# Altium schematic sheet FPGA_CONFIG.SchDoc(FPGA_CONFIG)
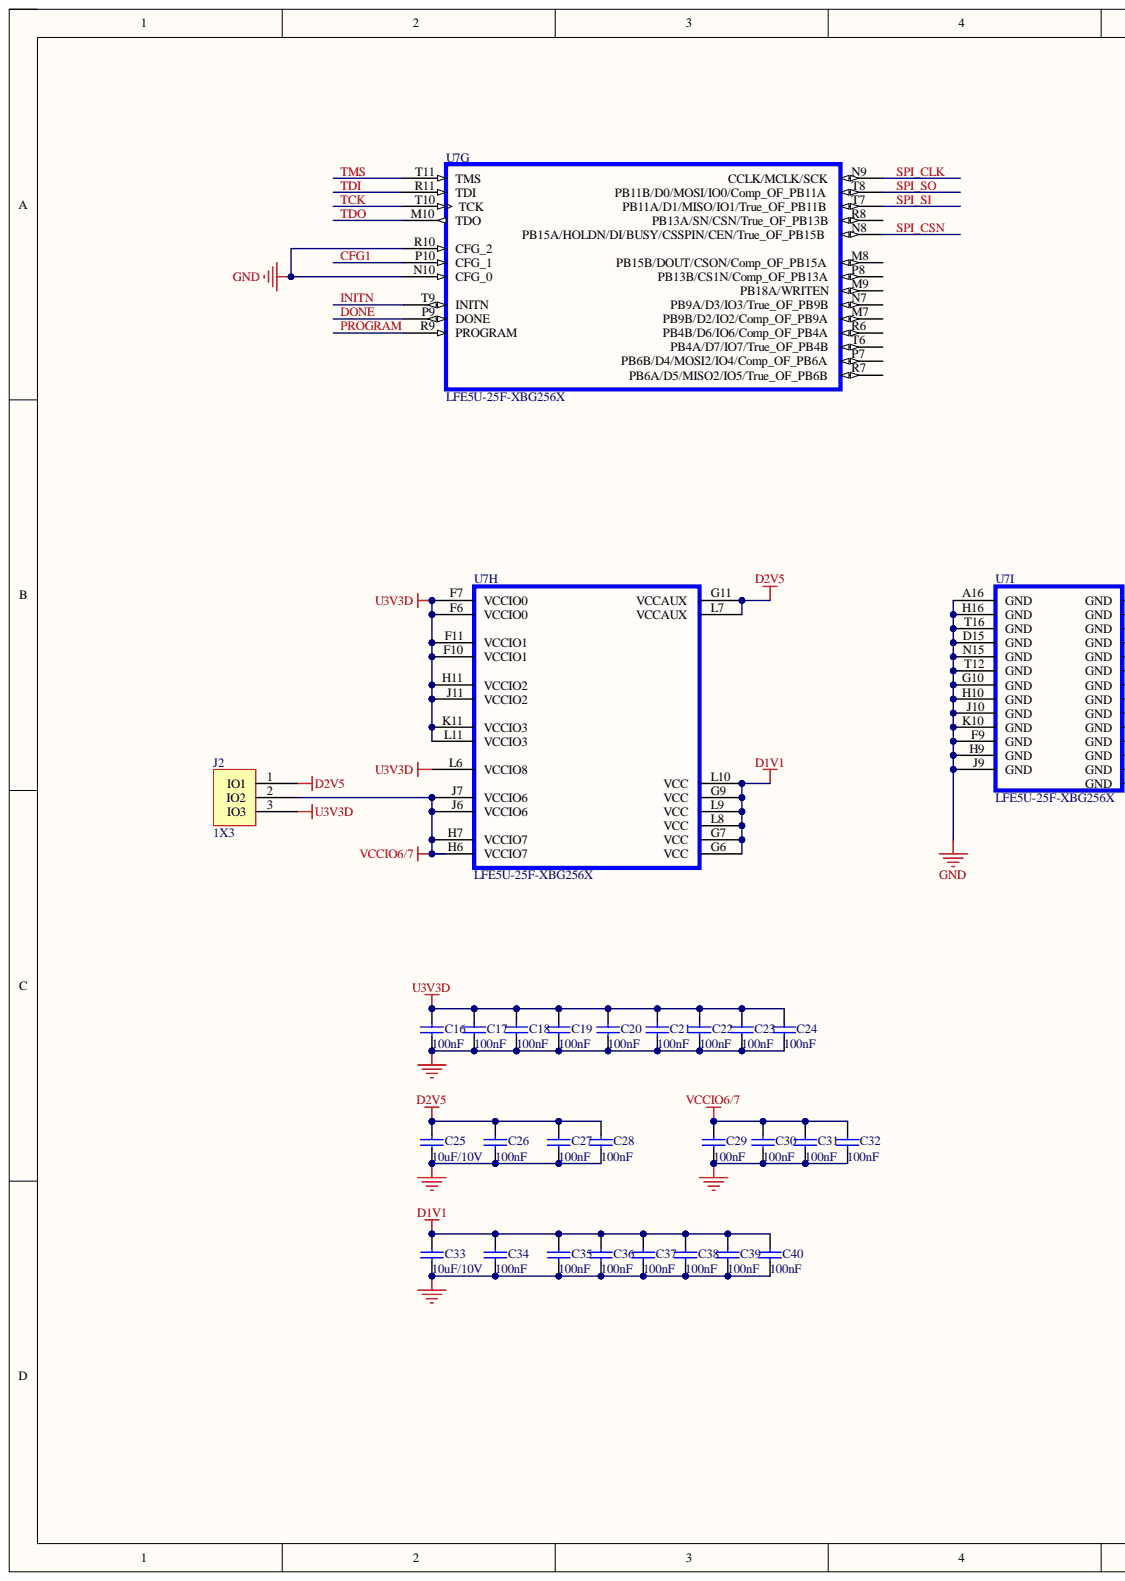
[diagram p0: FPGA_CONFIG.SchDoc, left]
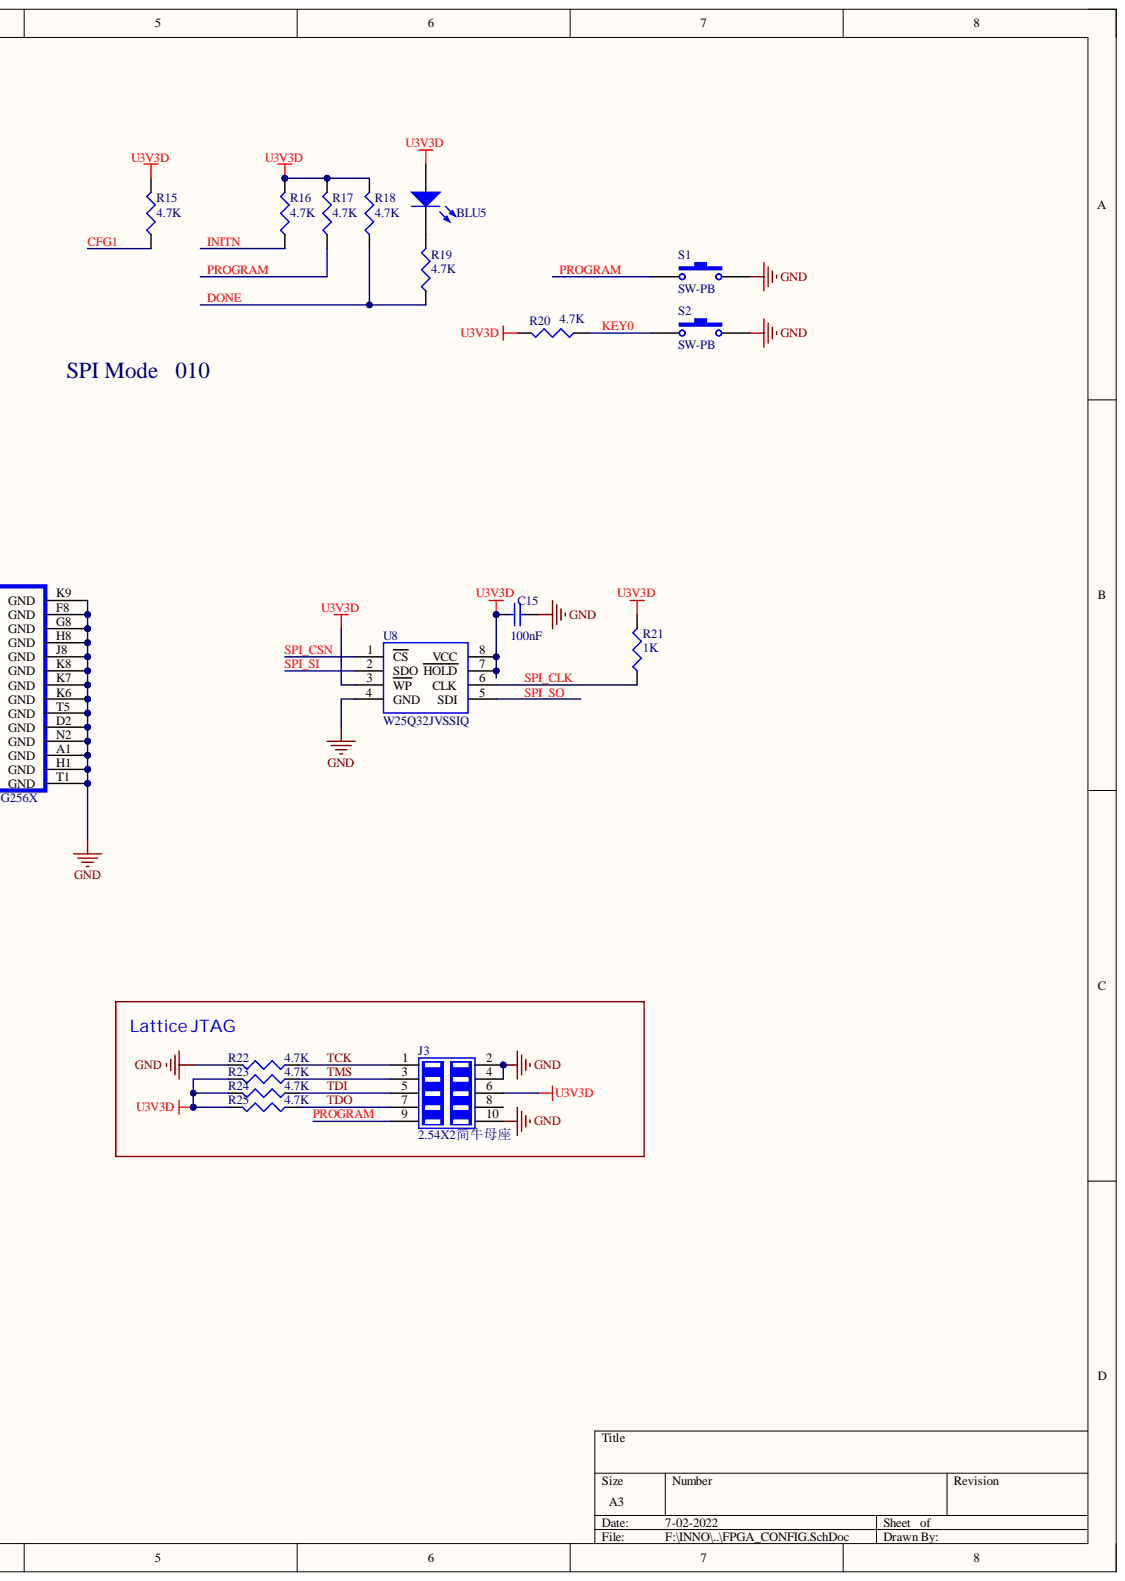
[diagram p1: FPGA_CONFIG.SchDoc, right]

## Parts
BLU5  LED  [0805-LED]  (value not drawn)
C15  100nF  [0402-C]
C16  100nF  [0402-C]
C17  100nF  [0402-C]
C18  100nF  [0402-C]
C19  100nF  [0402-C]
C20  100nF  [0402-C]
C21  100nF  [0402-C]
C22  100nF  [0402-C]
C23  100nF  [0402-C]
C24  100nF  [0402-C]
C25  10uF/10V  [0402-C]
C26  100nF  [0402-C]
C27  100nF  [0402-C]
C28  100nF  [0402-C]
C29  100nF  [0402-C]
C30  100nF  [0402-C]
C31  100nF  [0402-C]
C32  100nF  [0402-C]
C33  10uF/10V  [0402-C]
C34  100nF  [0402-C]
C35  100nF  [0402-C]
C36  100nF  [0402-C]
C37  100nF  [0402-C]
C38  100nF  [0402-C]
C39  100nF  [0402-C]
C40  100nF  [0402-C]
J2  1X3  [1x3P-2.54-D]
J3  2.54X2简牛母座  [2x5-2.54]
R15  4.7K  [0402-R]
R16  4.7K  [0402-R]
R17  4.7K  [0402-R]
R18  4.7K  [0402-R]
R19  4.7K  [0402-R]
R20  4.7K  [0402-R]
R21  1K  [0402-R]
R22  4.7K  [0402-R]
R23  4.7K  [0402-R]
R24  4.7K  [0402-R]
R25  4.7K  [0402-R]
S1  SW-PB  [anniu]
S2  SW-PB  [anniu]
U7  LFE5U-25F-XBG256X  [caBGA256]  (parts G,H,I)
U8  W25Q32JVSSIQ  [SOIC8-208MIL]

## Nets
D1V1: C33.2 C34.2 C35.2 C36.2 C37.2 C38.2 C39.2 C40.2 U7.G6(VCC) U7.G7(VCC) U7.G9(VCC) U7.L8(VCC) U7.L9(VCC) U7.L10(VCC)
D2V5: C25.2 C26.2 C27.2 C28.2 J2.1 U7.G11(VCCAUX) U7.L7(VCCAUX)
GND: C15.1 C16.1 C17.1 C18.1 C19.1 C20.1 C21.1 C22.1 C23.1 C24.1 C25.1 C26.1 C27.1 C28.1 C29.1 C30.1 C31.1 C32.1 C33.1 C34.1 C35.1 C36.1 C37.1 C38.1 C39.1 C40.1 J3.2 J3.4 J3.10 R22.2 S1.2 S2.2 U7.A1(GND) U7.A16(GND) U7.D2(GND) U7.D15(GND) U7.F8(GND) U7.F9(GND) U7.G8(GND) U7.G10(GND) U7.H1(GND) U7.H8(GND) U7.H9(GND) U7.H10(GND) U7.H16(GND) U7.J8(GND) U7.J9(GND) U7.J10(GND) U7.K6(GND) U7.K7(GND) U7.K8(GND) U7.K9(GND) U7.K10(GND) U7.N2(GND) U7.N10(CFG_0) U7.N15(GND) U7.R10(CFG_2) U7.T1(GND) U7.T5(GND) U7.T12(GND) U7.T16(GND) U8.4(GND)
U3V3D: BLU5.1 C15.2 C16.2 C17.2 C18.2 C19.2 C20.2 C21.2 C22.2 C23.2 C24.2 J2.3 J3.6 R15.2 R16.2 R17.2 R18.2 R20.2 R21.2 R23.2 R24.2 R25.2 U7.F6(VCCIO0) U7.F7(VCCIO0) U7.F10(VCCIO1) U7.F11(VCCIO1) U7.H11(VCCIO2) U7.J11(VCCIO2) U7.K11(VCCIO3) U7.L6(VCCIO8) U7.L11(VCCIO3) U8.3(WP) U8.7(HOLD) U8.8(VCC)
VCCIO6/7: C29.2 C30.2 C31.2 C32.2 J2.2 U7.H6(VCCIO7) U7.H7(VCCIO7) U7.J6(VCCIO6) U7.J7(VCCIO6)
CFG1: R15.1 U7.P10(CFG_1)
DONE: R18.1 R19.1 U7.P9(DONE)
INITN: R16.1 U7.T9(INITN)
KEY0: R20.1 S2.1
PROGRAM: J3.9 R17.1 S1.1 U7.R9(PROGRAM)
SPI_CLK: R21.1 U7.N9(CCLK/MCLK/SCK) U8.6(CLK)
SPI_CSN: U7.N8(PB15A/HOLDN/DI/BUSY/CSSPIN/CEN/True_OF_PB15B) U8.1(CS)
SPI_SI: U7.T7(PB11A/D1/MISO/IO1/True_OF_PB11B) U8.2(SDO)
SPI_SO: U7.T8(PB11B/D0/MOSI/IO0/Comp_OF_PB11A) U8.5(SDI)
TCK: J3.1 R22.1 U7.T10(TCK)
TDI: J3.5 R24.1 U7.R11(TDI)
TDO: J3.7 R25.1 U7.M10(TDO)
TMS: J3.3 R23.1 U7.T11(TMS)
NetBLU5_2: BLU5.2 R19.2

Not connected: J3 8; U7 M7(PB9B/D2/IO2/Comp_OF_PB9A) M8(PB15B/DOUT/CSON/Comp_OF_PB15A) M9(PB18A/WRITEN) N7(PB9A/D3/IO3/True_OF_PB9B) P7(PB6B/D4/MOSI2/IO4/Comp_OF_PB6A) P8(PB13B/CS1N/Comp_OF_PB13A) R6(PB4B/D6/IO6/Comp_OF_PB4A) R7(PB6A/D5/MISO2/IO5/True_OF_PB6B) R8(PB13A/SN/CSN/True_OF_PB13B) T6(PB4A/D7/IO7/True_OF_PB4B)

## Library data of the parts
BLU5 = LED: LED灯; Component Kind: Standard; Library Name: ctr_prj.SchLib
C15-C40 = Cap Semi: Capacitor (Semiconductor SIM Model); Component Kind: Standard; Library Name: YuanQi.SchLib
J2 = 1X3: 通用接插座子; Component Kind: Standard; Library Name: YuanQi.SchLib
J3 = JTAG: 通用JTAG接口; Component Kind: Standard; Library Name: YuanQi.SchLib
R15-R21 = RES: 电阻; Component Kind: Standard; Library Name: YuanQi.SchLib
R22-R25 = Res: Resistor; Component Kind: Standard; Library Name: Schlib.SchLib
S1 S2 = SW-PB: 按钮; Component Kind: Standard; Library Name: YuanQi.SchLib
U7 = LFE5U-25F-XBG256X: Lattice ECP FPGA，兼容LFE5U-45F-XBG256X; Component Kind: Standard; PackageVersion: Rev 1.1; RoHS: Pb-Free; Library Name: YuanQi.SchLib
U8 = S25FL064K: Serial Flash; Component Kind: Standard; Library Name: YuanQi.SchLib
